# Altium schematic sheet SENSE_MUXES.SchDoc
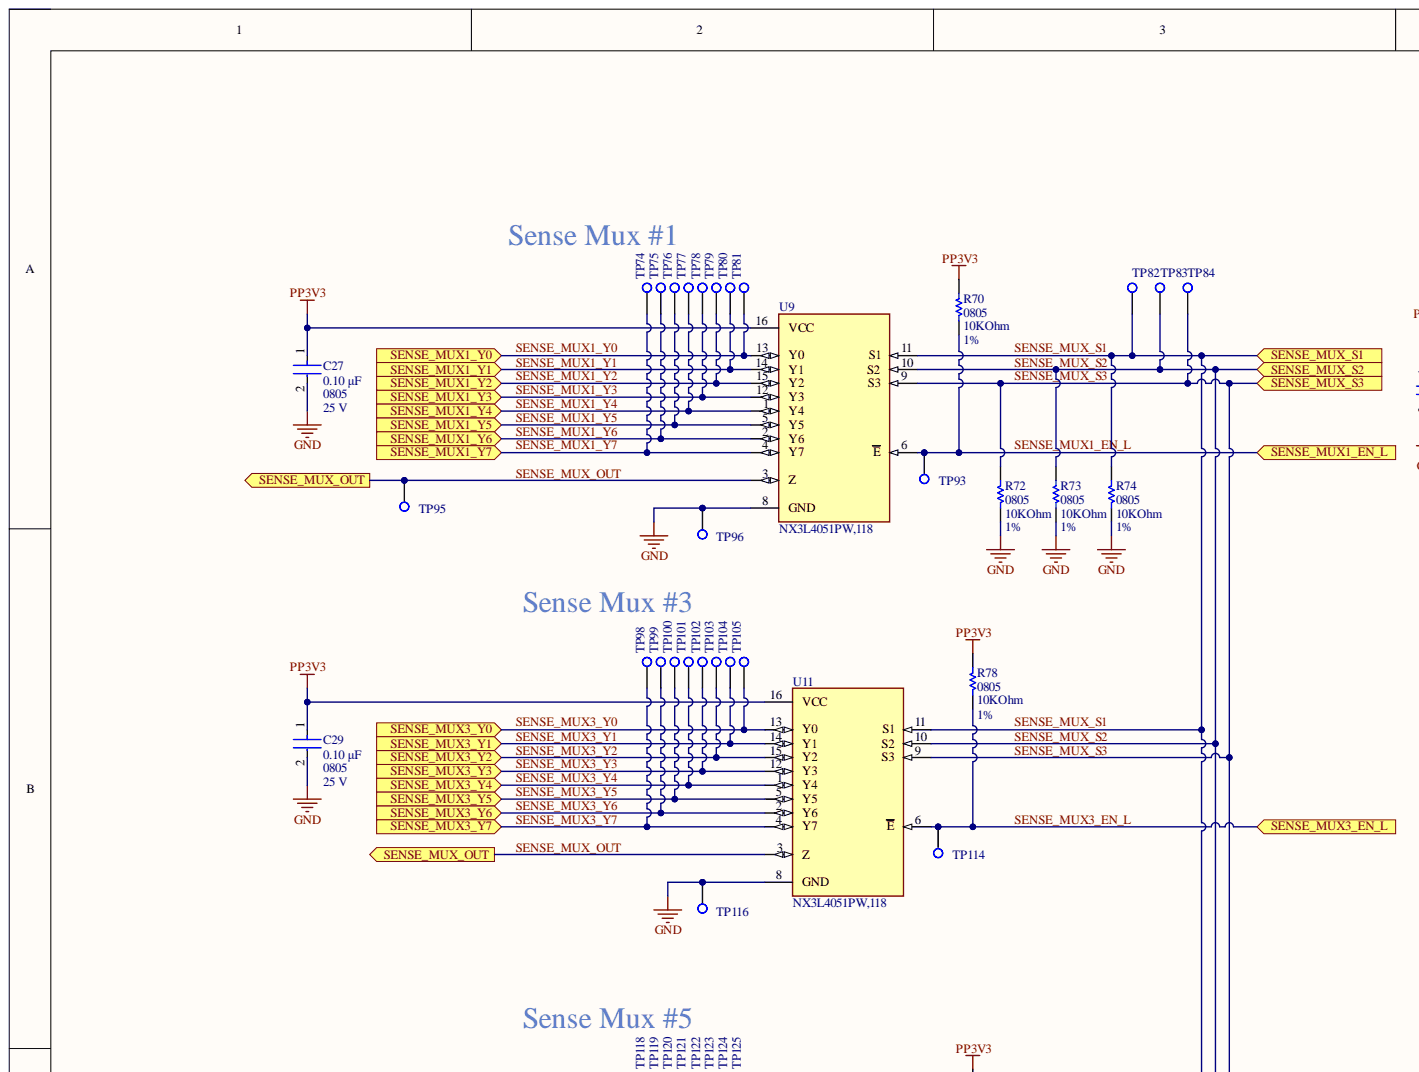
[diagram p0: SENSE_MUXES.SchDoc, top left]
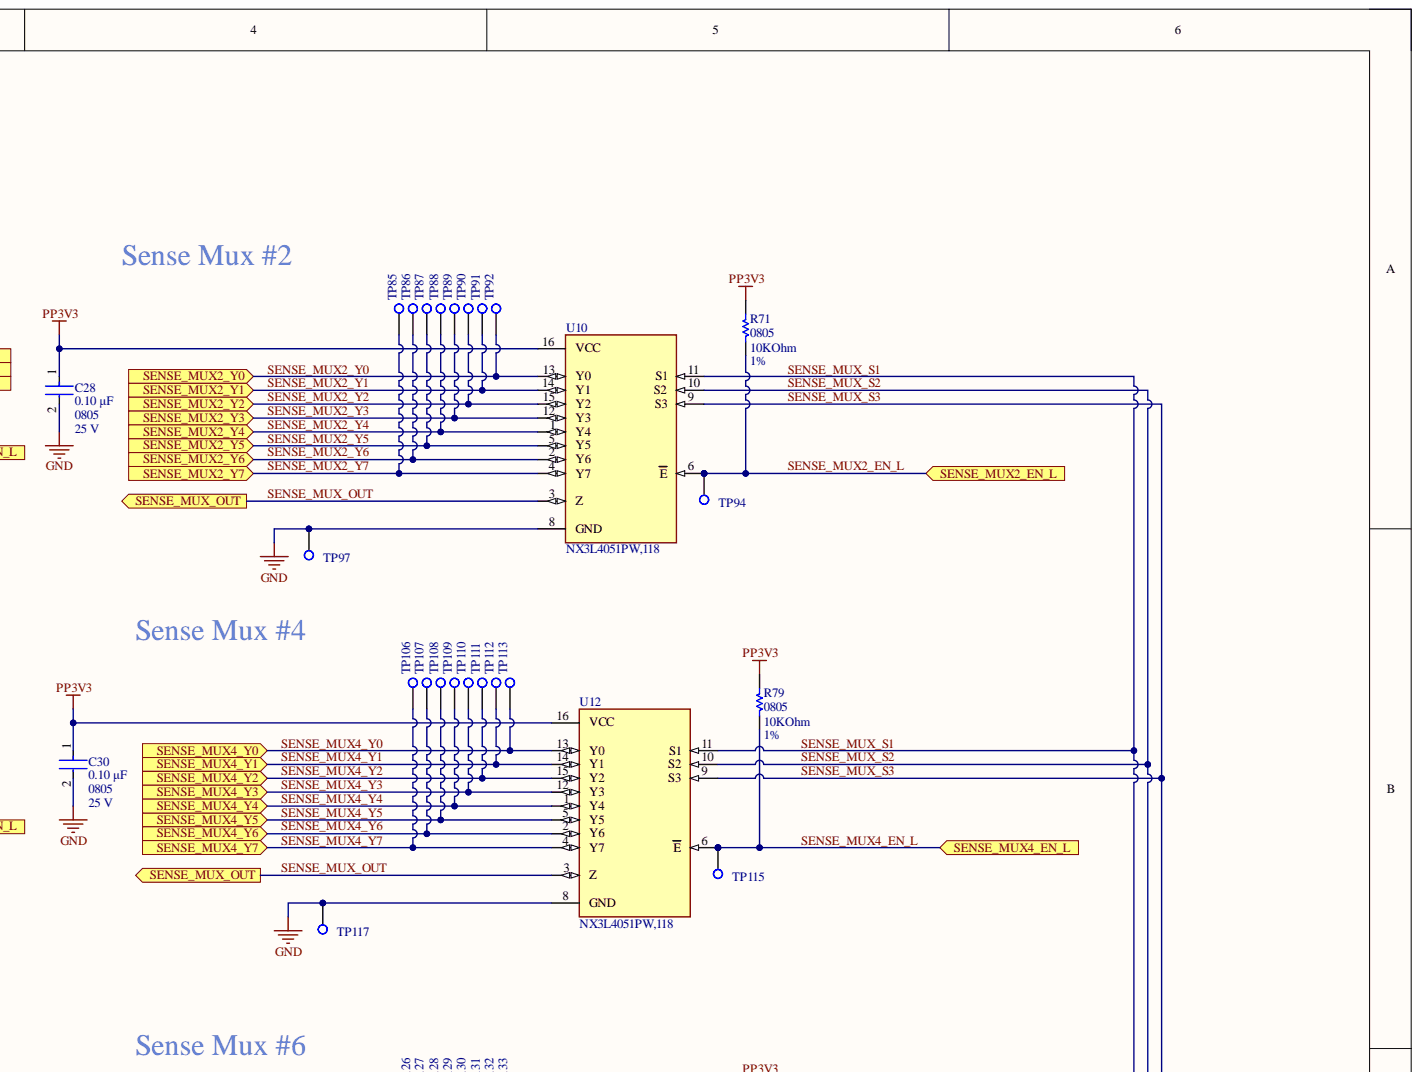
[diagram p1: SENSE_MUXES.SchDoc, top right]
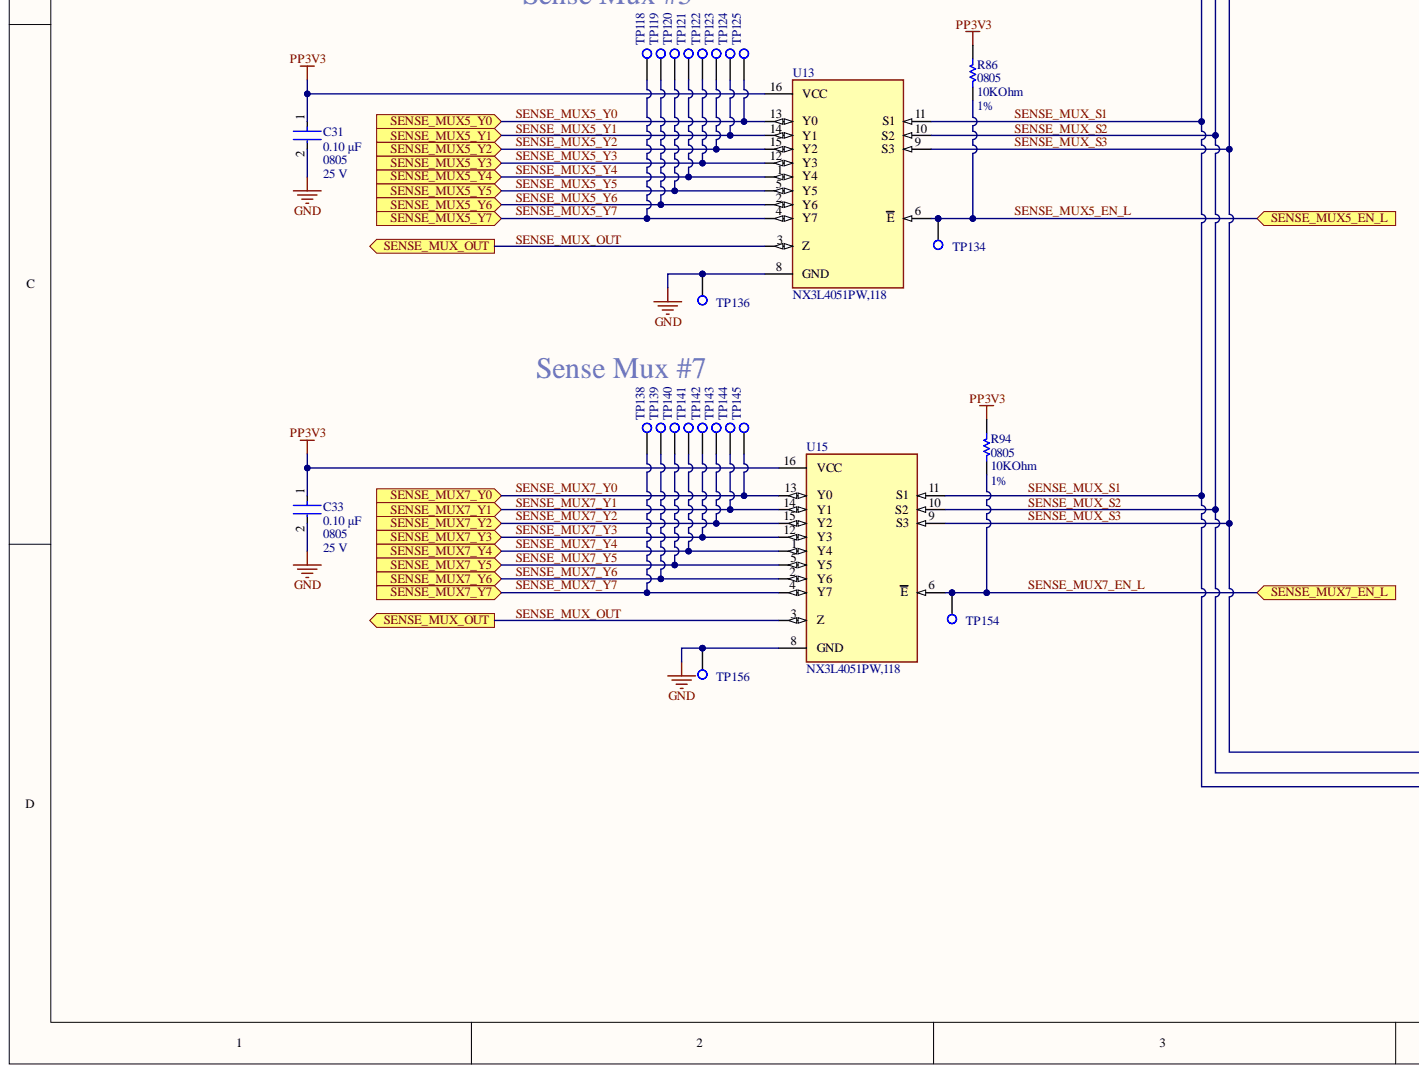
[diagram p2: SENSE_MUXES.SchDoc, bottom left]
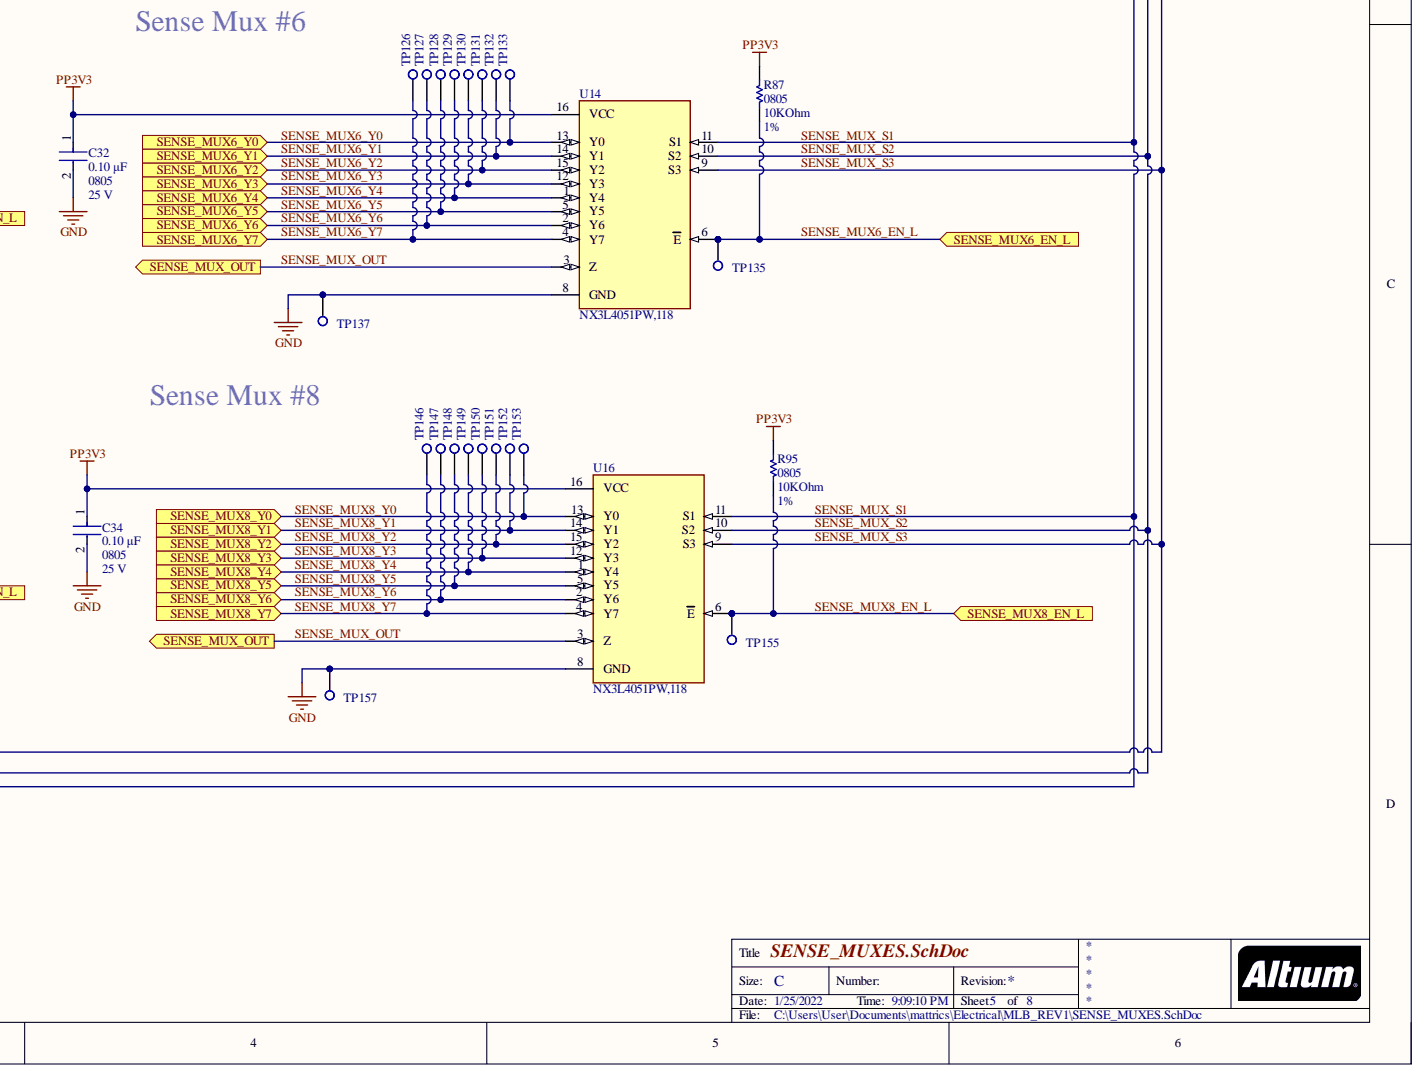
[diagram p3: SENSE_MUXES.SchDoc, bottom right]

## Parts
C27  value 0.10 μF  Capacitance: 0.10 μF  Package: 0805  Voltage Rating: 25 V  [FP-C0805C-DN-MFG]  (hidden comment: C0805C104M3RACTU)
C28  value 0.10 μF  Capacitance: 0.10 μF  Package: 0805  Voltage Rating: 25 V  [FP-C0805C-DN-MFG]  (hidden comment: C0805C104M3RACTU)
C29  value 0.10 μF  Capacitance: 0.10 μF  Package: 0805  Voltage Rating: 25 V  [FP-C0805C-DN-MFG]  (hidden comment: C0805C104M3RACTU)
C30  value 0.10 μF  Capacitance: 0.10 μF  Package: 0805  Voltage Rating: 25 V  [FP-C0805C-DN-MFG]  (hidden comment: C0805C104M3RACTU)
C31  value 0.10 μF  Capacitance: 0.10 μF  Package: 0805  Voltage Rating: 25 V  [FP-C0805C-DN-MFG]  (hidden comment: C0805C104M3RACTU)
C32  value 0.10 μF  Capacitance: 0.10 μF  Package: 0805  Voltage Rating: 25 V  [FP-C0805C-DN-MFG]  (hidden comment: C0805C104M3RACTU)
C33  value 0.10 μF  Capacitance: 0.10 μF  Package: 0805  Voltage Rating: 25 V  [FP-C0805C-DN-MFG]  (hidden comment: C0805C104M3RACTU)
C34  value 0.10 μF  Capacitance: 0.10 μF  Package: 0805  Voltage Rating: 25 V  [FP-C0805C-DN-MFG]  (hidden comment: C0805C104M3RACTU)
R70  value 10KOhm  Package Reference: 0805  Tolerance: 1%  [RESC2013X50X30NL20T20]  (hidden comment: CRCW080515K0FKTA)
R71  value 10KOhm  Package Reference: 0805  Tolerance: 1%  [RESC2013X50X30NL20T20]  (hidden comment: CRCW080515K0FKTA)
R72  value 10KOhm  Package Reference: 0805  Tolerance: 1%  [RESC2013X50X30NL20T20]  (hidden comment: CRCW080515K0FKTA)
R73  value 10KOhm  Package Reference: 0805  Tolerance: 1%  [RESC2013X50X30NL20T20]  (hidden comment: CRCW080515K0FKTA)
R74  value 10KOhm  Package Reference: 0805  Tolerance: 1%  [RESC2013X50X30NL20T20]  (hidden comment: CRCW080515K0FKTA)
R78  value 10KOhm  Package Reference: 0805  Tolerance: 1%  [RESC2013X50X30NL20T20]  (hidden comment: CRCW080515K0FKTA)
R79  value 10KOhm  Package Reference: 0805  Tolerance: 1%  [RESC2013X50X30NL20T20]  (hidden comment: CRCW080515K0FKTA)
R86  value 10KOhm  Package Reference: 0805  Tolerance: 1%  [RESC2013X50X30NL20T20]  (hidden comment: CRCW080515K0FKTA)
R87  value 10KOhm  Package Reference: 0805  Tolerance: 1%  [RESC2013X50X30NL20T20]  (hidden comment: CRCW080515K0FKTA)
R94  value 10KOhm  Package Reference: 0805  Tolerance: 1%  [RESC2013X50X30NL20T20]  (hidden comment: CRCW080515K0FKTA)
R95  value 10KOhm  Package Reference: 0805  Tolerance: 1%  [RESC2013X50X30NL20T20]  (hidden comment: CRCW080515K0FKTA)
TP74  RCU-0C  [TP+195X110]  (value not drawn)
TP75  RCU-0C  [TP+195X110]  (value not drawn)
TP76  RCU-0C  [TP+195X110]  (value not drawn)
TP77  RCU-0C  [TP+195X110]  (value not drawn)
TP78  RCU-0C  [TP+195X110]  (value not drawn)
TP79  RCU-0C  [TP+195X110]  (value not drawn)
TP80  RCU-0C  [TP+195X110]  (value not drawn)
TP81  RCU-0C  [TP+195X110]  (value not drawn)
TP82  RCU-0C  [TP+195X110]  (value not drawn)
TP83  RCU-0C  [TP+195X110]  (value not drawn)
TP84  RCU-0C  [TP+195X110]  (value not drawn)
TP85  RCU-0C  [TP+195X110]  (value not drawn)
TP86  RCU-0C  [TP+195X110]  (value not drawn)
TP87  RCU-0C  [TP+195X110]  (value not drawn)
TP88  RCU-0C  [TP+195X110]  (value not drawn)
TP89  RCU-0C  [TP+195X110]  (value not drawn)
TP90  RCU-0C  [TP+195X110]  (value not drawn)
TP91  RCU-0C  [TP+195X110]  (value not drawn)
TP92  RCU-0C  [TP+195X110]  (value not drawn)
TP93  RCU-0C  [TP+195X110]  (value not drawn)
TP94  RCU-0C  [TP+195X110]  (value not drawn)
TP95  RCU-0C  [TP+195X110]  (value not drawn)
TP96  RCU-0C  [TP+195X110]  (value not drawn)
TP97  RCU-0C  [TP+195X110]  (value not drawn)
TP98  RCU-0C  [TP+195X110]  (value not drawn)
TP99  RCU-0C  [TP+195X110]  (value not drawn)
TP100  RCU-0C  [TP+195X110]  (value not drawn)
TP101  RCU-0C  [TP+195X110]  (value not drawn)
TP102  RCU-0C  [TP+195X110]  (value not drawn)
TP103  RCU-0C  [TP+195X110]  (value not drawn)
TP104  RCU-0C  [TP+195X110]  (value not drawn)
TP105  RCU-0C  [TP+195X110]  (value not drawn)
TP106  RCU-0C  [TP+195X110]  (value not drawn)
TP107  RCU-0C  [TP+195X110]  (value not drawn)
TP108  RCU-0C  [TP+195X110]  (value not drawn)
TP109  RCU-0C  [TP+195X110]  (value not drawn)
TP110  RCU-0C  [TP+195X110]  (value not drawn)
TP111  RCU-0C  [TP+195X110]  (value not drawn)
TP112  RCU-0C  [TP+195X110]  (value not drawn)
TP113  RCU-0C  [TP+195X110]  (value not drawn)
TP114  RCU-0C  [TP+195X110]  (value not drawn)
TP115  RCU-0C  [TP+195X110]  (value not drawn)
TP116  RCU-0C  [TP+195X110]  (value not drawn)
TP117  RCU-0C  [TP+195X110]  (value not drawn)
TP118  RCU-0C  [TP+195X110]  (value not drawn)
TP119  RCU-0C  [TP+195X110]  (value not drawn)
TP120  RCU-0C  [TP+195X110]  (value not drawn)
TP121  RCU-0C  [TP+195X110]  (value not drawn)
TP122  RCU-0C  [TP+195X110]  (value not drawn)
TP123  RCU-0C  [TP+195X110]  (value not drawn)
TP124  RCU-0C  [TP+195X110]  (value not drawn)
TP125  RCU-0C  [TP+195X110]  (value not drawn)
TP126  RCU-0C  [TP+195X110]  (value not drawn)
TP127  RCU-0C  [TP+195X110]  (value not drawn)
TP128  RCU-0C  [TP+195X110]  (value not drawn)
TP129  RCU-0C  [TP+195X110]  (value not drawn)
TP130  RCU-0C  [TP+195X110]  (value not drawn)
TP131  RCU-0C  [TP+195X110]  (value not drawn)
TP132  RCU-0C  [TP+195X110]  (value not drawn)
TP133  RCU-0C  [TP+195X110]  (value not drawn)
TP134  RCU-0C  [TP+195X110]  (value not drawn)
TP135  RCU-0C  [TP+195X110]  (value not drawn)
TP136  RCU-0C  [TP+195X110]  (value not drawn)
TP137  RCU-0C  [TP+195X110]  (value not drawn)
TP138  RCU-0C  [TP+195X110]  (value not drawn)
TP139  RCU-0C  [TP+195X110]  (value not drawn)
TP140  RCU-0C  [TP+195X110]  (value not drawn)
TP141  RCU-0C  [TP+195X110]  (value not drawn)
TP142  RCU-0C  [TP+195X110]  (value not drawn)
TP143  RCU-0C  [TP+195X110]  (value not drawn)
TP144  RCU-0C  [TP+195X110]  (value not drawn)
TP145  RCU-0C  [TP+195X110]  (value not drawn)
TP146  RCU-0C  [TP+195X110]  (value not drawn)
TP147  RCU-0C  [TP+195X110]  (value not drawn)
TP148  RCU-0C  [TP+195X110]  (value not drawn)
TP149  RCU-0C  [TP+195X110]  (value not drawn)
TP150  RCU-0C  [TP+195X110]  (value not drawn)
TP151  RCU-0C  [TP+195X110]  (value not drawn)
TP152  RCU-0C  [TP+195X110]  (value not drawn)
TP153  RCU-0C  [TP+195X110]  (value not drawn)
TP154  RCU-0C  [TP+195X110]  (value not drawn)
TP155  RCU-0C  [TP+195X110]  (value not drawn)
TP156  RCU-0C  [TP+195X110]  (value not drawn)
TP157  RCU-0C  [TP+195X110]  (value not drawn)
U9  NX3L4051PW,118  [SOP65P640X110-16N - duplicate]
U10  NX3L4051PW,118  [SOP65P640X110-16N - duplicate]
U11  NX3L4051PW,118  [SOP65P640X110-16N - duplicate]
U12  NX3L4051PW,118  [SOP65P640X110-16N - duplicate]
U13  NX3L4051PW,118  [SOP65P640X110-16N - duplicate]
U14  NX3L4051PW,118  [SOP65P640X110-16N - duplicate]
U15  NX3L4051PW,118  [SOP65P640X110-16N - duplicate]
U16  NX3L4051PW,118  [SOP65P640X110-16N - duplicate]

## Ports
SENSE_MUX1_EN_L, SENSE_MUX1_Y0, SENSE_MUX1_Y1, SENSE_MUX1_Y2, SENSE_MUX1_Y3, SENSE_MUX1_Y4, SENSE_MUX1_Y5, SENSE_MUX1_Y6, SENSE_MUX1_Y7, SENSE_MUX2_EN_L, SENSE_MUX2_Y0, SENSE_MUX2_Y1, SENSE_MUX2_Y2, SENSE_MUX2_Y3, SENSE_MUX2_Y4, SENSE_MUX2_Y5, SENSE_MUX2_Y6, SENSE_MUX2_Y7, SENSE_MUX3_EN_L, SENSE_MUX3_Y0, SENSE_MUX3_Y1, SENSE_MUX3_Y2, SENSE_MUX3_Y3, SENSE_MUX3_Y4, SENSE_MUX3_Y5, SENSE_MUX3_Y6, SENSE_MUX3_Y7, SENSE_MUX4_EN_L, SENSE_MUX4_Y0, SENSE_MUX4_Y1, SENSE_MUX4_Y2, SENSE_MUX4_Y3, SENSE_MUX4_Y4, SENSE_MUX4_Y5, SENSE_MUX4_Y6, SENSE_MUX4_Y7, SENSE_MUX5_EN_L, SENSE_MUX5_Y0, SENSE_MUX5_Y1, SENSE_MUX5_Y2, SENSE_MUX5_Y3, SENSE_MUX5_Y4, SENSE_MUX5_Y5, SENSE_MUX5_Y6, SENSE_MUX5_Y7, SENSE_MUX6_EN_L, SENSE_MUX6_Y0, SENSE_MUX6_Y1, SENSE_MUX6_Y2, SENSE_MUX6_Y3, SENSE_MUX6_Y4, SENSE_MUX6_Y5, SENSE_MUX6_Y6, SENSE_MUX6_Y7, SENSE_MUX7_EN_L, SENSE_MUX7_Y0, SENSE_MUX7_Y1, SENSE_MUX7_Y2, SENSE_MUX7_Y3, SENSE_MUX7_Y4, SENSE_MUX7_Y5, SENSE_MUX7_Y6, SENSE_MUX7_Y7, SENSE_MUX8_EN_L, SENSE_MUX8_Y0, SENSE_MUX8_Y1, SENSE_MUX8_Y2, SENSE_MUX8_Y3, SENSE_MUX8_Y4, SENSE_MUX8_Y5, SENSE_MUX8_Y6, SENSE_MUX8_Y7, SENSE_MUX_OUT, SENSE_MUX_S1, SENSE_MUX_S2, SENSE_MUX_S3

## Nets
GND: C27.2 C28.2 C29.2 C30.2 C31.2 C32.2 C33.2 C34.2 R72.1 R73.1 R74.1 TP96.1 TP97.1 TP116.1 TP117.1 TP136.1 TP137.1 TP156.1 TP157.1 U9.8(GND) U10.8(GND) U11.8(GND) U12.8(GND) U13.8(GND) U14.8(GND) U15.8(GND) U16.8(GND)
PP3V3: C27.1 C28.1 C29.1 C30.1 C31.1 C32.1 C33.1 C34.1 R70.2 R71.2 R78.2 R79.2 R86.2 R87.2 R94.2 R95.2 U9.16(VCC) U10.16(VCC) U11.16(VCC) U12.16(VCC) U13.16(VCC) U14.16(VCC) U15.16(VCC) U16.16(VCC)
SENSE_MUX1_EN_L: R70.1 TP93.1 U9.6(E) ; port SENSE_MUX1_EN_L
SENSE_MUX1_Y0: TP81.1 U9.13(Y0) ; port SENSE_MUX1_Y0
SENSE_MUX1_Y1: TP80.1 U9.14(Y1) ; port SENSE_MUX1_Y1
SENSE_MUX1_Y2: TP79.1 U9.15(Y2) ; port SENSE_MUX1_Y2
SENSE_MUX1_Y3: TP78.1 U9.12(Y3) ; port SENSE_MUX1_Y3
SENSE_MUX1_Y4: TP77.1 U9.1(Y4) ; port SENSE_MUX1_Y4
SENSE_MUX1_Y5: TP76.1 U9.5(Y5) ; port SENSE_MUX1_Y5
SENSE_MUX1_Y6: TP75.1 U9.2(Y6) ; port SENSE_MUX1_Y6
SENSE_MUX1_Y7: TP74.1 U9.4(Y7) ; port SENSE_MUX1_Y7
SENSE_MUX2_EN_L: R71.1 TP94.1 U10.6(E) ; port SENSE_MUX2_EN_L
SENSE_MUX2_Y0: TP92.1 U10.13(Y0) ; port SENSE_MUX2_Y0
SENSE_MUX2_Y1: TP91.1 U10.14(Y1) ; port SENSE_MUX2_Y1
SENSE_MUX2_Y2: TP90.1 U10.15(Y2) ; port SENSE_MUX2_Y2
SENSE_MUX2_Y3: TP89.1 U10.12(Y3) ; port SENSE_MUX2_Y3
SENSE_MUX2_Y4: TP88.1 U10.1(Y4) ; port SENSE_MUX2_Y4
SENSE_MUX2_Y5: TP87.1 U10.5(Y5) ; port SENSE_MUX2_Y5
SENSE_MUX2_Y6: TP86.1 U10.2(Y6) ; port SENSE_MUX2_Y6
SENSE_MUX2_Y7: TP85.1 U10.4(Y7) ; port SENSE_MUX2_Y7
SENSE_MUX3_EN_L: R78.1 TP114.1 U11.6(E) ; port SENSE_MUX3_EN_L
SENSE_MUX3_Y0: TP105.1 U11.13(Y0) ; port SENSE_MUX3_Y0
SENSE_MUX3_Y1: TP104.1 U11.14(Y1) ; port SENSE_MUX3_Y1
SENSE_MUX3_Y2: TP103.1 U11.15(Y2) ; port SENSE_MUX3_Y2
SENSE_MUX3_Y3: TP102.1 U11.12(Y3) ; port SENSE_MUX3_Y3
SENSE_MUX3_Y4: TP101.1 U11.1(Y4) ; port SENSE_MUX3_Y4
SENSE_MUX3_Y5: TP100.1 U11.5(Y5) ; port SENSE_MUX3_Y5
SENSE_MUX3_Y6: TP99.1 U11.2(Y6) ; port SENSE_MUX3_Y6
SENSE_MUX3_Y7: TP98.1 U11.4(Y7) ; port SENSE_MUX3_Y7
SENSE_MUX4_EN_L: R79.1 TP115.1 U12.6(E) ; port SENSE_MUX4_EN_L
SENSE_MUX4_Y0: TP113.1 U12.13(Y0) ; port SENSE_MUX4_Y0
SENSE_MUX4_Y1: TP112.1 U12.14(Y1) ; port SENSE_MUX4_Y1
SENSE_MUX4_Y2: TP111.1 U12.15(Y2) ; port SENSE_MUX4_Y2
SENSE_MUX4_Y3: TP110.1 U12.12(Y3) ; port SENSE_MUX4_Y3
SENSE_MUX4_Y4: TP109.1 U12.1(Y4) ; port SENSE_MUX4_Y4
SENSE_MUX4_Y5: TP108.1 U12.5(Y5) ; port SENSE_MUX4_Y5
SENSE_MUX4_Y6: TP107.1 U12.2(Y6) ; port SENSE_MUX4_Y6
SENSE_MUX4_Y7: TP106.1 U12.4(Y7) ; port SENSE_MUX4_Y7
SENSE_MUX5_EN_L: R86.1 TP134.1 U13.6(E) ; port SENSE_MUX5_EN_L
SENSE_MUX5_Y0: TP125.1 U13.13(Y0) ; port SENSE_MUX5_Y0
SENSE_MUX5_Y1: TP124.1 U13.14(Y1) ; port SENSE_MUX5_Y1
SENSE_MUX5_Y2: TP123.1 U13.15(Y2) ; port SENSE_MUX5_Y2
SENSE_MUX5_Y3: TP122.1 U13.12(Y3) ; port SENSE_MUX5_Y3
SENSE_MUX5_Y4: TP121.1 U13.1(Y4) ; port SENSE_MUX5_Y4
SENSE_MUX5_Y5: TP120.1 U13.5(Y5) ; port SENSE_MUX5_Y5
SENSE_MUX5_Y6: TP119.1 U13.2(Y6) ; port SENSE_MUX5_Y6
SENSE_MUX5_Y7: TP118.1 U13.4(Y7) ; port SENSE_MUX5_Y7
SENSE_MUX6_EN_L: R87.1 TP135.1 U14.6(E) ; port SENSE_MUX6_EN_L
SENSE_MUX6_Y0: TP133.1 U14.13(Y0) ; port SENSE_MUX6_Y0
SENSE_MUX6_Y1: TP132.1 U14.14(Y1) ; port SENSE_MUX6_Y1
SENSE_MUX6_Y2: TP131.1 U14.15(Y2) ; port SENSE_MUX6_Y2
SENSE_MUX6_Y3: TP130.1 U14.12(Y3) ; port SENSE_MUX6_Y3
SENSE_MUX6_Y4: TP129.1 U14.1(Y4) ; port SENSE_MUX6_Y4
SENSE_MUX6_Y5: TP128.1 U14.5(Y5) ; port SENSE_MUX6_Y5
SENSE_MUX6_Y6: TP127.1 U14.2(Y6) ; port SENSE_MUX6_Y6
SENSE_MUX6_Y7: TP126.1 U14.4(Y7) ; port SENSE_MUX6_Y7
SENSE_MUX7_EN_L: R94.1 TP154.1 U15.6(E) ; port SENSE_MUX7_EN_L
SENSE_MUX7_Y0: TP145.1 U15.13(Y0) ; port SENSE_MUX7_Y0
SENSE_MUX7_Y1: TP144.1 U15.14(Y1) ; port SENSE_MUX7_Y1
SENSE_MUX7_Y2: TP143.1 U15.15(Y2) ; port SENSE_MUX7_Y2
SENSE_MUX7_Y3: TP142.1 U15.12(Y3) ; port SENSE_MUX7_Y3
SENSE_MUX7_Y4: TP141.1 U15.1(Y4) ; port SENSE_MUX7_Y4
SENSE_MUX7_Y5: TP140.1 U15.5(Y5) ; port SENSE_MUX7_Y5
SENSE_MUX7_Y6: TP139.1 U15.2(Y6) ; port SENSE_MUX7_Y6
SENSE_MUX7_Y7: TP138.1 U15.4(Y7) ; port SENSE_MUX7_Y7
SENSE_MUX8_EN_L: R95.1 TP155.1 U16.6(E) ; port SENSE_MUX8_EN_L
SENSE_MUX8_Y0: TP153.1 U16.13(Y0) ; port SENSE_MUX8_Y0
SENSE_MUX8_Y1: TP152.1 U16.14(Y1) ; port SENSE_MUX8_Y1
SENSE_MUX8_Y2: TP151.1 U16.15(Y2) ; port SENSE_MUX8_Y2
SENSE_MUX8_Y3: TP150.1 U16.12(Y3) ; port SENSE_MUX8_Y3
SENSE_MUX8_Y4: TP149.1 U16.1(Y4) ; port SENSE_MUX8_Y4
SENSE_MUX8_Y5: TP148.1 U16.5(Y5) ; port SENSE_MUX8_Y5
SENSE_MUX8_Y6: TP147.1 U16.2(Y6) ; port SENSE_MUX8_Y6
SENSE_MUX8_Y7: TP146.1 U16.4(Y7) ; port SENSE_MUX8_Y7
SENSE_MUX_OUT: TP95.1 U9.3(Z) U10.3(Z) U11.3(Z) U12.3(Z) U13.3(Z) U14.3(Z) U15.3(Z) U16.3(Z) ; port SENSE_MUX_OUT
SENSE_MUX_S1: R74.2 TP82.1 U9.11(S1) U10.11(S1) U11.11(S1) U12.11(S1) U13.11(S1) U14.11(S1) U15.11(S1) U16.11(S1) ; port SENSE_MUX_S1
SENSE_MUX_S2: R73.2 TP83.1 U9.10(S2) U10.10(S2) U11.10(S2) U12.10(S2) U13.10(S2) U14.10(S2) U15.10(S2) U16.10(S2) ; port SENSE_MUX_S2
SENSE_MUX_S3: R72.2 TP84.1 U9.9(S3) U10.9(S3) U11.9(S3) U12.9(S3) U13.9(S3) U14.9(S3) U15.9(S3) U16.9(S3) ; port SENSE_MUX_S3

## Library data of the parts
C27-C34 = CMP-2007-00282-2: CAP CER 0.1UF 25V 20% X7R 0805; Manufacturer: KEMET; Material: Ceramic; Temperature Coefficient: 30ppm/°C; Tolerance: 0.2; Automotive: No; Category: Cap; Component Kind: Standard; ComponentLink2Description: Datasheet; ComponentLink2URL: https://content.kemet.com/datasheets/KEM_C1003_C0G_SMD.pdf; Device Class L1: Passive Components; Device Class L2: Capacitors; Device Class L3: Ceramic Capacitors; Digikey Description: CAP CER 0.1UF 25V 20% X7R 0805; Height: 0.88mm; Ipc Land Pattern Name: CAPC200125X78; Lead Free: Yes; Library Name: Altium Content Vault; Manufacturer Part Number: C0805C104M3RACTU; Mouser Description: Multilayer Ceramic Capacitors MLCC - SMD/SMT 25volts 0.1uF X7R 20%; Octopart: https://octopart.com/c0805c104m3ractu-kemet-9138612; RoHS: TRUE; Supplier: Digi-Key; Supplier Part Number: 399-C0805C104M3RAC7800CT-ND; Temperature: -55°C to +125°C; Temperature Characteristic: X7R; Temperature Range High: +125°C; Temperature Range Low: -55°C
R70-R74 R78 R79 R86 R87 R94 R95 = CMP-2001-04968-1: Manufacturer: Vishay Dale; Component Kind: Standard; Library Name: Altium Content Vault; Manufacturer Part Number: CRCW080515K0FKTA; Supplier: Digi-Key; Supplier Part Number: 541-3019-1-ND
TP74-TP157 = RCU-0C: Natural PC Test Point , Plating Surface Mount Mounting Type; Manufacturer: TE Connectivity AMP Connectors; Check_prices: https://www.snapeda.com/parts/RCU-0C/TE%20Connectivity/view-part/4791537/?ref=eda; Component Kind: Standard; Library Name: MLBSchLib.SchLib; Manufacturer Part Number: RCU-0C; Package: SON-6 TE Connectivity AMP Connectors; Purchase-URL: https://pricing.snapeda.com/search/part/RCU-0C/?ref=eda; SnapEDA_Link: https://www.snapeda.com/parts/RCU-0C/TE%20Connectivity/view-part/4791537/?ref=snap; Supplier: Digi-Key; Supplier Part Number: A106145CT-ND
U9-U16 = NX3L4051PW,118: 1 Circuit IC Switch 8:1 750mOhm 16-TSSOP; Manufacturer: NXP USA Inc.; MF: NXP USA; Check_prices: https://www.snapeda.com/parts/NX3L4051PW,118/NXP%20USA%20Inc./view-part/648693/?ref=eda; Component Kind: Standard; Digi-Key_Part_Number: 568-12393-1-ND; Library Name: MLBSchLib.SchLib; Manufacturer Part Number: NX3L4051PW,118; MP: NX3L4051PW,118; Package: TSSOP-16 NXP USA; Purchase-URL: https://pricing.snapeda.com/search/part/NX3L4051PW,118/?ref=eda; SnapEDA_Link: https://www.snapeda.com/parts/NX3L4051PW,118/NXP%20USA%20Inc./view-part/648693/?ref=snap; Supplier: Digi-Key; Supplier Part Number: 568-12393-1-ND
